# Altium schematic sheet TOP Sheet.SchDoc
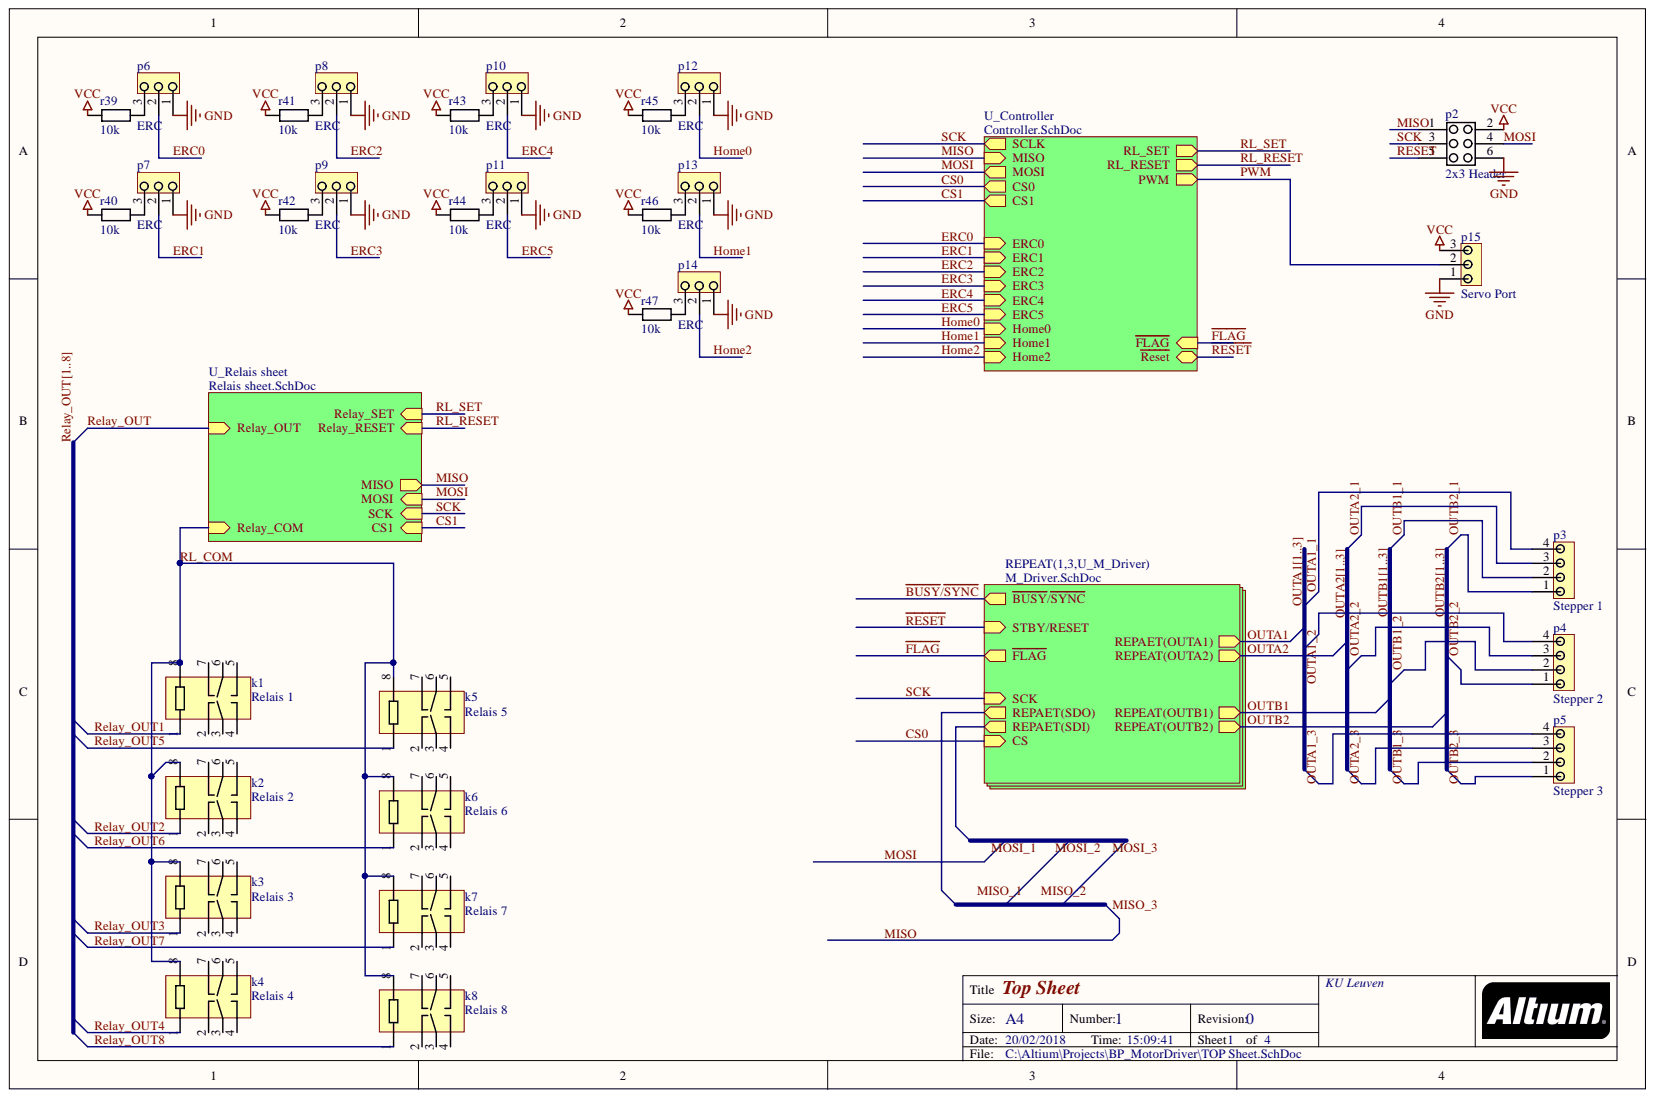
[diagram p0: TOP Sheet.SchDoc]

## Parts
k1  Relais 1  [THT8-Relay]
k2  Relais 2  [THT8-Relay]
k3  Relais 3  [THT8-Relay]
k4  Relais 4  [THT8-Relay]
k5  Relais 5  [THT8-Relay]
k6  Relais 6  [THT8-Relay]
k7  Relais 7  [THT8-Relay]
k8  Relais 8  [THT8-Relay]
p2  2x3 Header  [2x3-HeaderPin]
p3  Stepper 1  [WtB-F-4]
p4  Stepper 2  [WtB-F-4]
p5  Stepper 3  [WtB-F-4]
p6  ERC  [1X3 Headerpin Angled]
p7  ERC  [1X3 Headerpin Angled]
p8  ERC  [1X3 Headerpin Angled]
p9  ERC  [1X3 Headerpin Angled]
p10  ERC  [1X3 Headerpin Angled]
p11  ERC  [1X3 Headerpin Angled]
p12  ERC  [1X3 Headerpin Angled]
p13  ERC  [1X3 Headerpin Angled]
p14  ERC  [1X3 Headerpin Angled]
p15  Servo Port  [1X3 Headerpin Angled]
r39  10k  [0603]
r40  10k  [0603]
r41  10k  [0603]
r42  10k  [0603]
r43  10k  [0603]
r44  10k  [0603]
r45  10k  [0603]
r46  10k  [0603]
r47  10k  [0603]

## Nets
GND: p2.6 p6.1 p7.1 p8.1 p9.1 p10.1 p11.1 p12.1 p13.1 p14.1 p15.1
VCC: p2.2 p15.3 r39.1 r40.1 r41.1 r42.1 r43.1 r44.1 r45.1 r46.1 r47.1
ERC0: p6.2
ERC1: p7.2
ERC2: p8.2
ERC3: p9.2
ERC4: p10.2
ERC5: p11.2
Home0: p12.2
Home1: p13.2
Home2: p14.2
MISO: p2.1
MOSI: p2.4
OUTA1_1: p3.4
OUTA1_3: p5.4
OUTA2_1: p3.3
OUTA2_2: p4.3
OUTA2_3: p5.3
OUTB1_1: p3.2
OUTB1_2: p4.2
OUTB1_3: p5.2
OUTB2_1: p3.1
OUTB2_3: p5.1
PWM: p15.2
Relay_OUT1: k1.1  [also labelled RELAY_OUT]
Relay_OUT2: k2.1  [also labelled RELAY_OUT]
Relay_OUT3: k3.1  [also labelled RELAY_OUT]
Relay_OUT4: k4.1  [also labelled RELAY_OUT]
Relay_OUT5: k5.1  [also labelled RELAY_OUT]
Relay_OUT6: k6.1  [also labelled RELAY_OUT]
Relay_OUT7: k7.1  [also labelled RELAY_OUT]
Relay_OUT8: k8.1  [also labelled RELAY_OUT]
RESET: p2.5
RL_COM: k1.8 k2.8 k3.8 k4.8 k5.8 k6.8 k7.8 k8.8
SCK: p2.3
Netk1_2: k1.2
Netk1_3: k1.3
Netk1_4: k1.4
Netk1_5: k1.5
Netk1_6: k1.6
Netk1_7: k1.7
Netk2_2: k2.2
Netk2_3: k2.3
Netk2_4: k2.4
Netk2_5: k2.5
Netk2_6: k2.6
Netk2_7: k2.7
Netk3_2: k3.2
Netk3_3: k3.3
Netk3_4: k3.4
Netk3_5: k3.5
Netk3_6: k3.6
Netk3_7: k3.7
Netk4_2: k4.2
Netk4_3: k4.3
Netk4_4: k4.4
Netk4_5: k4.5
Netk4_6: k4.6
Netk4_7: k4.7
Netk5_2: k5.2
Netk5_3: k5.3
Netk5_4: k5.4
Netk5_5: k5.5
Netk5_6: k5.6
Netk5_7: k5.7
Netk6_2: k6.2
Netk6_3: k6.3
Netk6_4: k6.4
Netk6_5: k6.5
Netk6_6: k6.6
Netk6_7: k6.7
Netk7_2: k7.2
Netk7_3: k7.3
Netk7_4: k7.4
Netk7_5: k7.5
Netk7_6: k7.6
Netk7_7: k7.7
Netk8_2: k8.2
Netk8_3: k8.3
Netk8_4: k8.4
Netk8_5: k8.5
Netk8_6: k8.6
Netk8_7: k8.7
Netp4_1: p4.1
Netp4_4: p4.4
Netp6_3: p6.3 r39.2
Netp7_3: p7.3 r40.2
Netp8_3: p8.3 r41.2
Netp9_3: p9.3 r42.2
Netp10_3: p10.3 r43.2
Netp11_3: p11.3 r44.2
Netp12_3: p12.3 r45.2
Netp13_3: p13.3 r46.2
Netp14_3: p14.3 r47.2
~{BUSY}/~{SYNC}:
CS0:
CS1:
~{FLAG}:
MISO_1:
MISO_2:
MISO_3:
MOSI_1:
MOSI_2:
MOSI_3:
N00107:
N00108:
N00117:
N00120:
OUTA1:
OUTA1[1..3]:
OUTA1_2:
OUTA2:
OUTA2[1..3]:
OUTA11:
OUTA12:
OUTA13:
OUTA21:
OUTA22:
OUTA23:
OUTB1:
OUTB1[1..3]:
OUTB2:
OUTB2[1..3]:
OUTB2_2:
OUTB11:
OUTB12:
OUTB13:
OUTB21:
OUTB22:
OUTB23:
~{RESET}:
Relay_OUT:
REPAET(SDI):
REPAET(SDO):
RL_RESET:
RL_SET:

## Library data of the parts
k1-k8 = IM03NS: Signal Relay; Component Kind: Standard; Library Name: TE Connectivity.SchLib
p2 = 2x3 Header: Header Pin; Component Kind: Standard; Library Name: Multicomp.SchLib
p3-p5 = Wire-to-Board connector 4 contacts: Wire-to-Board connector; Component Kind: Standard; Library Name: Wurth Electronic.SchLib
p6-p15 = Wire-to-Board 3 Pin: Component Kind: Standard; Library Name: Wurth Electronic.SchLib
r39-r47 = 0603 Resistor: ...R; Component Kind: Standard; Library Name: Generic R-C.SchLib
